# Altium schematic sheet PS_v1.SchDoc
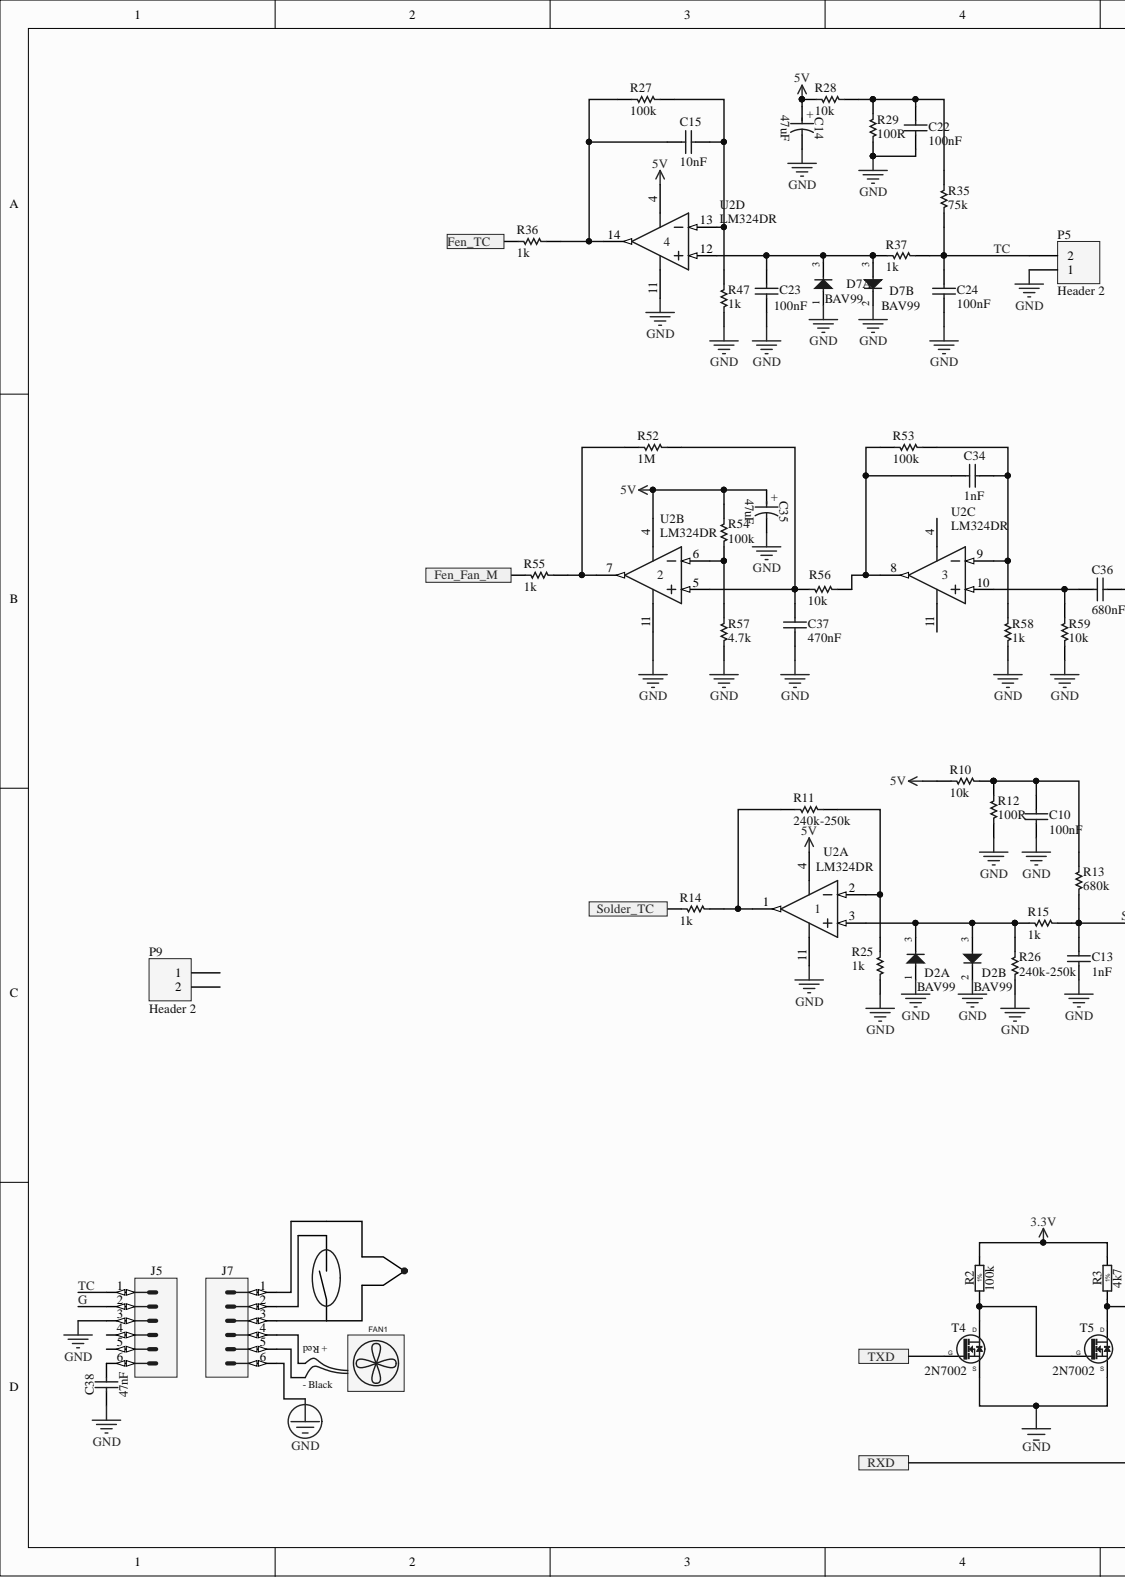
[diagram p0: PS_v1.SchDoc, left]
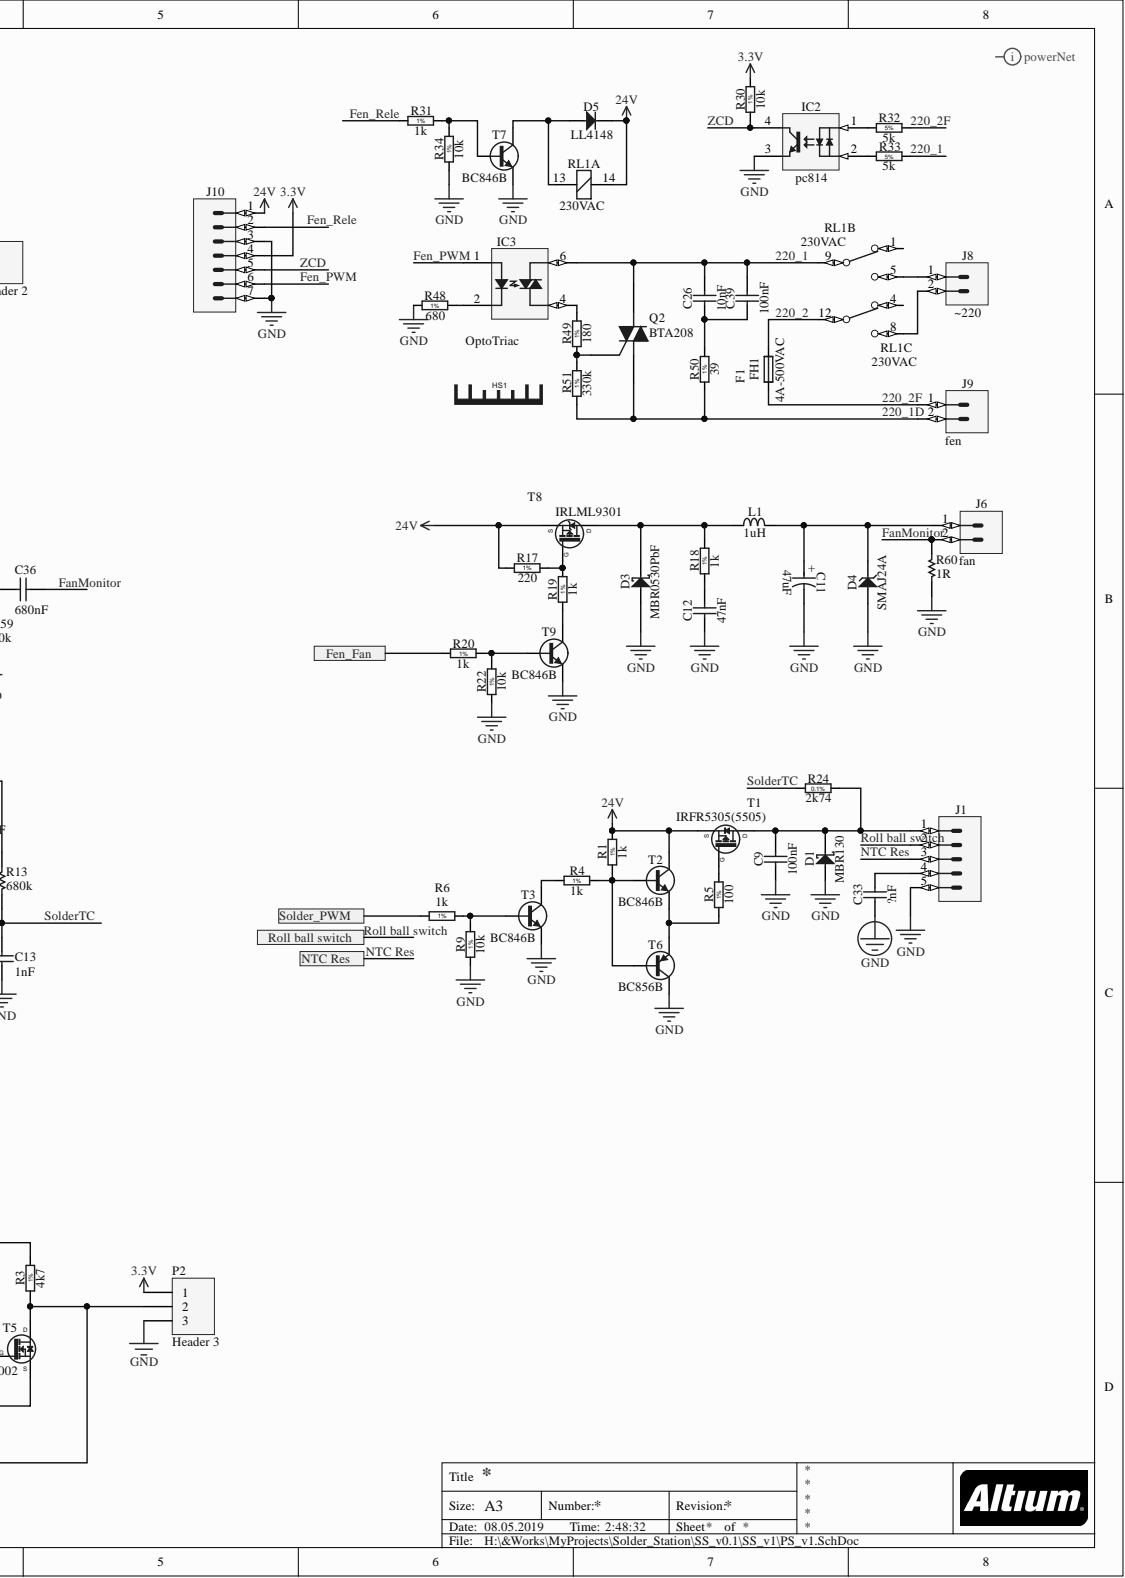
[diagram p1: PS_v1.SchDoc, right]

## Parts
C9  100nF  [CAPC2012X135N]
C10  value 100nF  [0805]  (hidden comment: C0805)
C11  47uF  [CAPAE660X540N]
C12  47nF  [CAPC2013X100N]
C13  value 1nF  [0805]  (hidden comment: C0805)
C14  47uF  [CAPAE660X540N]
C15  value 10nF  [0805]  (hidden comment: C0805)
C22  value 100nF  [0805]  (hidden comment: C0805)
C23  value 100nF  [0805]  (hidden comment: C0805)
C24  value 100nF  [0805]  (hidden comment: C0805)
C26  10nF  [CAPR1500-500X1800X1100]
C33  ?nF  [CAPC2013X100N]
C34  value 1nF  [0805]  (hidden comment: C0805)
C35  47uF  [CAPAE660X540N]
C36  value 680nF  [0805]  (hidden comment: C0805)
C37  value 470nF  [0805]  (hidden comment: C0805)
C38  47nF  [CAPC2013X100N]
C39  100nF  [CAPR2250-850X2650X1650]
D1  MBR130  [SOD-123FL]
D2  BAV99  [SOT95P240X110-3N]  (parts A,B)
D3  MBR0530PbF  [SOD3715X145N]
D4  SMAJ24A  [DIOM5226X262N]
D5  LL4148  [DIOMELF5025N]
D7  BAV99  [SOT95P240X110-3N]  (parts A,B)
F1  4A-500VAC  [FUSE_10X38_CERAMIC_H]
FAN1  Fan No Pin  [FAN_SUNON_MC30060V1-000U-A99]  (value not drawn)
FH1  Fuse Holder  [FUSH_BUSSMANN_1A3400-09_A]  (value not drawn)
HS1  Heat Sink No Pad  [HSINK_FISCHER_FK 242 SA 220 O_V]  (value not drawn)
IC2  pc814  [SOIC254P977X406-4N]
IC3  OptoTriac  [FAIRCHILD_MDIP 6L (SMD)]
J1  KK®  [MOLEX_22-27-2051]  (value not drawn)
J5  KK®  [MOLEX_22-27-2061]  (value not drawn)
J6  fan  [HARWIN_M22-2010205]
J7  KK®  [MOLEX_22-27-2061]  (value not drawn)
J8  ~220  [PHOENIX_1755736]
J9  fen  [PHOENIX_1755736]
J10  KK®  [MOLEX_22-04-1071]  (value not drawn)
L1  1uH  [INDC2012X110N]
P2  Header 3  [HDR1X3]
P5  Header 2  [HDR1X2]
P9  Header 2  [HDR1X2]
Q2  BTA208  [TO-220-V]
R1  1k  [RESC2012X60N]
R2  100k  [RESC2012X60N]
R3  4k7  [RESC2012X60N]
R4  1k  [RESC2012X60N]
R5  100  [RESC2012X60N]
R6  1k  [RESC2012X60N]
R9  10k  [RESC2012X60N]
R10  value 10k  [ERA-0805]  (hidden comment: ERA6Y)
R11  value 240k-250k  [ERA-0805]  (hidden comment: ERA6Y)
R12  value 100R  [ERA-0805]  (hidden comment: ERA6Y)
R13  value 680k  [ERA-0805]  (hidden comment: ERA6Y)
R14  value 1k  [ERA-0805]  (hidden comment: ERA6Y)
R15  value 1k  [ERA-0805]  (hidden comment: ERA6Y)
R17  220  [RESC2012X60N]
R18  1k  [RESC2012X60N]
R19  1k  [RESC2012X60N]
R20  1k  [RESC2012X60N]
R22  10k  [RESC2012X60N]
R24  2k74  [RESC2012X60N]
R25  value 1k  [ERA-0805]  (hidden comment: ERA6Y)
R26  value 240k-250k  [ERA-0805]  (hidden comment: ERA6Y)
R27  value 100k  [ERA-0805]  (hidden comment: ERA6Y)
R28  value 10k  [ERA-0805]  (hidden comment: ERA6Y)
R29  value 100R  [ERA-0805]  (hidden comment: ERA6Y)
R30  10k  [RESC2012X60N]
R31  1k  [RESC2012X60N]
R32  5k  [RES_WELWYN_W31]
R33  5k  [RES_VISHAY_AC03]
R34  10k  [RESC2012X60N]
R35  value 75k  [ERA-0805]  (hidden comment: ERA6Y)
R36  value 1k  [ERA-0805]  (hidden comment: ERA6Y)
R37  value 1k  [ERA-0805]  (hidden comment: ERA6Y)
R47  value 1k  [ERA-0805]  (hidden comment: ERA6Y)
R48  680  [RESC2012X60N]
R49  180  [RESC2012X60N]
R50  39  [RESC3216X65N]
R51  330k  [RESC2012X60N]
R52  value 1M  [ERA-0805]  (hidden comment: ERA6Y)
R53  value 100k  [ERA-0805]  (hidden comment: ERA6Y)
R54  value 100k  [ERA-0805]  (hidden comment: ERA6Y)
R55  value 1k  [ERA-0805]  (hidden comment: ERA6Y)
R56  value 10k  [ERA-0805]  (hidden comment: ERA6Y)
R57  value 4.7k  [ERA-0805]  (hidden comment: ERA6Y)
R58  value 1k  [ERA-0805]  (hidden comment: ERA6Y)
R59  value 10k  [ERA-0805]  (hidden comment: ERA6Y)
R60  value 1R  [ERA-0805]  (hidden comment: ERA6Y)
RL1  230VAC  [REL_FINDER_55.32]  (parts A,B,C)
T1  IRFR5305(5505)  [TO228P991X239-3N-R552X520]
T2  BC846B  [SOT95P230X110-3N]
T3  BC846B  [SOT95P230X110-3N]
T4  2N7002  [SOT95P240X130-3N]
T5  2N7002  [SOT95P240X130-3N]
T6  BC856B  [SOT95P230X110-3N]
T7  BC846B  [SOT95P230X110-3N]
T8  IRLML9301  [SOT95P237X112-3N]
T9  BC846B  [SOT95P230X110-3N]
U2  LM324DR  [D014_N]  (parts A,B,C,D)

## Ports
Fen_Fan, Fen_Fan_M, Fen_TC, NTC Res, Roll ball switch, RXD, Solder_PWM, Solder_TC, TXD

## Nets
3.3V: J10.4 P2.1 R2.2 R3.2 R30.2
5V: C14.1 C35.1 R10.1 R28.2 R54.2 U2.4
24V: D5.1 J10.1 R1.2 R17.1 RL1.14 T1.2 T2.3 T8.2
GND: C9.1 C10.1 C11.2 C12.1 C13.1 C14.2 C22.1 C23.1 C24.1 C33.1 C35.2 C37.1 C38.1 D1.2 D2.1 D2.2 D3.2 D4.2 D7.1 D7.2 IC2.3 J1.5 J5.3 J7.6 J10.3 J10.7 P2.3 P5.1 R9.1 R12.2 R22.1 R25.2 R26.2 R29.2 R34.1 R47.2 R48.1 R57.1 R58.1 R59.1 R60.1 T3.2 T4.2 T5.2 T6.3 T7.2 T9.2 U2.11
220_1: C26.2 C39.2 IC3.6 Q2.2 R33.2 RL1.9
220_1D: J9.2 Q2.1 R50.1 R51.1
220_2: FH1.2 RL1.12
220_2F: FH1.1 J9.1 R32.2
FanMonitor: C36.2 J6.2 R60.2
Fen_Fan: R20.1 ; port Fen_Fan
Fen_Fan_M: R55.1 ; port Fen_Fan_M
Fen_PWM: IC3.1 J10.6
Fen_Rele: J10.2 R31.1
Fen_TC: R36.2 ; port Fen_TC
G: J5.2
NTC Res: J1.3 ; port NTC Res
Roll ball switch: J1.2 ; port Roll ball switch
RXD: P2.2 R3.1 T5.3 ; port RXD
Solder_PWM: R6.1 ; port Solder_PWM
Solder_TC: R14.2 ; port Solder_TC
SolderTC: C13.2 R13.1 R15.2 R24.1
TC: C24.2 J5.1 P5.2 R35.2 R37.1
TXD: T4.1 ; port TXD
ZCD: IC2.4 J10.5 R30.1
NetC9_2: C9.2 D1.1 J1.1 R24.2 T1.3
NetC10_2: C10.2 R10.2 R12.1 R13.2
NetC11_1: C11.1 D4.1 J6.1 L1.2
NetC12_2: C12.2 R18.1
NetC15_1: C15.1 R27.2 R36.1 U2.14
NetC15_2: C15.2 R27.1 R47.1 U2.13
NetC22_2: C22.2 R28.1 R29.1 R35.1
NetC23_2: C23.2 D7.3 R37.2 U2.12
NetC26_1: C26.1 C39.1 R50.2
NetC33_2: C33.2 J1.4
NetC34_1: C34.1 R53.1 R56.2 U2.8
NetC34_2: C34.2 R53.2 R58.2 U2.9
NetC36_1: C36.1 R59.2 U2.10
NetC37_2: C37.2 R52.2 R56.1 U2.5
NetC38_2: C38.2 J5.6
NetD2_3: D2.3 R15.1 R26.1 U2.3
NetD3_1: D3.1 L1.1 R18.2 T8.3
NetD5_2: D5.2 RL1.13 T7.3
NetIC2_1: IC2.1 R32.1
NetIC2_2: IC2.2 R33.1
NetIC3_2: IC3.2 R48.2
NetIC3_4: IC3.4 R49.2
NetJ8_1: J8.1 RL1.5
NetJ8_2: J8.2 RL1.8
NetQ2_3: Q2.3 R49.1 R51.2
NetR1_1: R1.1 R4.2 T2.1 T6.1
NetR2_1: R2.1 T4.3 T5.1
NetR4_1: R4.1 T3.3
NetR5_1: R5.1 T2.2 T6.2
NetR5_2: R5.2 T1.1
NetR6_2: R6.2 R9.2 T3.1
NetR11_1: R11.1 R14.1 U2.1
NetR11_2: R11.2 R25.1 U2.2
NetR17_2: R17.2 R19.2 T8.1
NetR19_1: R19.1 T9.3
NetR20_2: R20.2 R22.2 T9.1
NetR31_2: R31.2 R34.2 T7.1
NetR52_1: R52.1 R55.2 U2.7
NetR54_1: R54.1 R57.2 U2.6
N00013:

Not connected: J5 4 5; J7 1 2 3 4 5; P9 1 2; RL1 1 4

## Library data of the parts
C9 = CC0805_100NF_50V_10%_X7R: Non-polarised capacitor; Manufacturer: GENERIC; Manufacturer1 Part Number: C2012X7R1H104K085AA; Part Description: SMD Multilayer Chip Ceramic Capacitor; Database Table Name: Capacitors SMD; TC: X7R; Tolerance: ±10%; Voltage: 50V; Case: 0805; Component Kind: Standard; Component Type: Standard; CreateDate: 03.12.2007; HelpURL: \\cern.ch\dfs\Applications\Altium\Datasheets\CC0805_X7R_TDK_C.pdf; LatestRevisionDate: 22.04.2015; Library Name: Capacitors.DbLib; Manufacturer1 ComponentHeight: 1mm; Manufacturer1 Example: TDK; Manufacturer Part Number: CC0805_100NF_50V_10%_X7R; Mounted: Yes; Part Number: CC0805_100NF_50V_10%_X7R; Pin Count: 2; Sense: No; SMD: Yes; Socket: No; Status: Preferred; Value: 100nF; Author: CERN DEM JLC
C10 C13 C15 C22-C24 C34 C36 C37 = C0805: Ceramic Chip Capacitor - Standard; Note: Footprint corresponds to dimensions for bulk cassette packaging. This case size has tightened tolerances.; Code_IPC: 2012[0805]; Code_JEITA: 0805; Component Kind: Standard; ComponentLink1Description: Manufacturer Link; ComponentLink1URL: http://www.kemet.com/; ComponentLink2Description: Datasheet; ComponentLink2URL: http://www.kemet.com/kemet/web/homepage/kechome.nsf/vapubfilesname/F3102TA.pdf/$file/F3102TA.pdf; DatasheetDocument: Mar-2001; LatestRevisionDate: 19-Mar-2004; LatestRevisionNote: Silkscreen size reduced.; Library Name: KEMET Chip Capacitor.IntLib; PackageDocument: Mar-2001; PackageReference: 0805; Published: 17-Jul-2002; Signal Integrity: C0805; Simulation: CAP; Publisher: Altium Limited
C11 = CAE660-540_47UF_35V_20%: Polarised capacitor; Manufacturer: PANASONIC; Manufacturer Part Number: EEEFK1V470AP; Part Description: Aluminum Electrolytic SMT Capacitor; Database Table Name: Capacitors SMD; Tolerance: ±20%; Voltage: 35V; Case: D; ComponentHeight: 5.8mm; Component Kind: Standard; Component Type: Standard; CreateDate: 03.12.2007; HelpURL: \\cern.ch\dfs\Applications\Altium\Datasheets\CAE_PANASONIC_FK.pdf; LatestRevisionDate: 05.11.2014; Library Name: Capacitors.DbLib; Mounted: Yes; Part Number: CAE660-540_47UF_35V_20%; Pin Count: 2; Sense: No; SMD: Yes; Socket: No; Status: Not Recommended; Value: 47uF; Author: CERN DEM JLC
C12 C33 C38 = CC0805_47NF_50V_10%_X7R: Non-polarised capacitor; Manufacturer: GENERIC; Manufacturer1 Part Number: C0805F473K5RACTU; Part Description: SMD Multilayer Chip Ceramic Capacitor; Database Table Name: Capacitors SMD; TC: X7R; Tolerance: ±10%; Voltage: 50V; Case: 0805; Component Kind: Standard; Component Type: Standard; CreateDate: 04.12.2013; HelpURL: \\cern.ch\dfs\Applications\Altium\Datasheets\CC0805_X7R_KEMET.pdf; LatestRevisionDate: 04.12.2013; Library Name: Capacitors.DbLib; Manufacturer1 ComponentHeight: 1mm; Manufacturer1 Example: KEMET; Manufacturer Part Number: CC0805_47NF_50V_10%_X7R; Mounted: Yes; Part Number: CC0805_47NF_50V_10%_X7R; Pin Count: 2; Sense: No; SMD: Yes; Socket: No; Status: Preferred; Author: CERN DEM JLC
  C12 C38: Value: 47nF
  C33: Value: ?nF
C14 C35 = CAE660-540_47UF_16V_20%: Polarised capacitor; Manufacturer: PANASONIC; Manufacturer Part Number: EEEFK1C470AP; Part Description: Aluminum Electrolytic SMT Capacitor; Database Table Name: Capacitors SMD; Tolerance: ±20%; Voltage: 16V; Case: D; ComponentHeight: 5.8mm; Component Kind: Standard; Component Type: Standard; CreateDate: 03.12.2007; HelpURL: \\cern.ch\dfs\Applications\Altium\Datasheets\CAE_PANASONIC_FK.pdf; LatestRevisionDate: 05.11.2014; Library Name: Capacitors.DbLib; Mounted: Yes; Part Number: CAE660-540_47UF_16V_20%; Pin Count: 2; Sense: No; SMD: Yes; Socket: No; Status: Not Recommended; Value: 47uF; Author: CERN DEM JLC
C26 = CPPR_10NF_300VAC_20%_1500-500X1800X1100: Non-polarised capacitor; Manufacturer: WIMA; Manufacturer Part Number: MKY22W21004B00MSSD; Part Description: Metallized Polypropylene RFI Capacitor Class Y2 (MKP-Y2); Database Table Name: Capacitors THD; Tolerance: ±20%; Voltage: 300VAC; Case: LS15 W5 x L18 x H11; ComponentHeight: 11mm; Component Kind: Standard; Component Type: Standard; CreateDate: 05.06.2008; HelpURL: \\cern.ch\dfs\Applications\Altium\Datasheets\CPPR_WIMA_MKP-Y2.pdf; LatestRevisionDate: 02.02.2012; Library Name: Capacitors.DbLib; Mounted: Yes; Part Number: CPPR_10NF_300VAC_20%_1500-500X1800X1100; Pin Count: 2; Sense: No; SMD: No; Socket: No; Status: None; Value: 10nF; Author: CERN DEM JLC
C39 = CPPR_100NF_300VAC_20%_TDK_B32023: Non-polarised capacitor; Manufacturer: TDK; Manufacturer Part Number: B32023A3104M000; Part Description: EMI Suppression Metallized Polypropylene Film Capacitor, Class  Y2; Database Table Name: Capacitors THD; Tolerance: ±20%; Voltage: 300VAC; Case: LS22.5 W8.5 x L26.5 x H16.5; ComponentHeight: 16.5mm; Component Kind: Standard; Component Type: Standard; CreateDate: 20.02.2017; HelpURL: \\cern.ch\dfs\Applications\Altium\Datasheets\CPPR_TDK_B32023.pdf; LatestRevisionDate: 20.04.2015; Library Name: Capacitors.DbLib; Mounted: Yes; Part Number: CPPR_100NF_300VAC_20%_TDK_B32023; Pin Count: 2; Sense: No; SMD: No; Socket: No; Status: None; Value: 100nF; Author: CERN DEM JLC
D1 = MBR140SFT1G: Schottky Diode; Manufacturer: ON SEMICONDUCTOR; Part Description: Schottky Power Rectifier; Bonding: No; Database Table Name: Diodes; Power: 1A; PressFit: No; Voltage: 40V; Case: SOD-123FL; ComponentHeight: 1.0mm; Component Kind: Standard; Component Type: Standard; CreateDate: 06.04.2009; Device: MBR130; HelpURL: \\cern.ch\dfs\Applications\Altium\Datasheets\MBR140SFT1G.pdf; LatestRevisionDate: 06.04.2009; Library Name: ICs And Semiconductors.DbLib; Manufacturer Part Number: MBR140SFT1G; Mounted: Yes; PackageDescription: Small Outline Diode Flat Lead (SOD-123FL), Body; 2.70mm L X 1.65mm W X 1.0mm H; Part Number: MBR140SFT1G; Pin Count: 2; Sense: No; SMD: Yes; Socket: No; Author: CERN DEM JLC
D2 D7 = BAV99: Serial Diode; Manufacturer: FAIRCHILD SEMICONDUCTOR; Part Description: Dual Series Signal Diode; Bonding: No; Database Table Name: Diodes; Power: 350mW; PressFit: No; Voltage: 70V; Case: SOT23-3; ComponentHeight: 1.1mm; Component Kind: Standard; Component Type: Standard; CreateDate: 06.05.2008; Device: BAV99; HelpURL: \\cern.ch\dfs\Applications\Altium\Datasheets\BAV99.pdf; LatestRevisionDate: 27.09.2012; Library Name: ICs And Semiconductors.DbLib; Manufacturer Part Number: BAV99; Mounted: Yes; PackageDescription: SOT23 3-Leads, Pitch 0.95mm - Body 1.4x3mm, IPC Medium Density; Part Number: BAV99; Pin Count: 3; Sense: No; SMD: Yes; Socket: No; Author: CERN DEM JLC
D3 = MBR0530PbF: Schottky Diode; Manufacturer: VISHAY HIGH POWER PRODUCTS; Bonding: No; Database Table Name: Diodes; Power: 500mA; PressFit: No; Voltage: 30V; Case: SOD-123; ComponentHeight: 1.45mm; Component Kind: Standard; Component Type: Standard; CreateDate: 26.01.2010; Device: MBR0530PbF; HelpURL: \\cern.ch\dfs\Applications\Altium\Datasheets\MBR0530PBF.pdf; LatestRevisionDate: 26.01.2010; Library Name: ICs And Semiconductors.DbLib; Manufacturer Part Number: MBR0530PbF; Mounted: Yes; PackageDescription: SOD Diode (SOD-123); 2.70mm W X 1.55mm L X 1.45mm H body, IPC Medium Density; Part Description: Schottky Diode; Part Number: MBR0530PbF; Pin Count: 2; Sense: No; SMD: Yes; Socket: No; Author: CERN DEM JLC
D4 = SMAJ24A: Transient Voltage Suppressor Diode (Uni-Directional); Manufacturer: BOURNS; Part Description: Surface Mount Uni-directional Transient Voltage Suppressor Diode; Bonding: No; Database Table Name: Diodes; Power: 400W; PressFit: No; Voltage: 24V; Case: DO-214AC; ComponentHeight: 2.62mm; Component Kind: Standard; Component Type: Standard; CreateDate: 27.06.2011; Device: SMAJ24A; HelpURL: \\cern.ch\dfs\Applications\Altium\Datasheets\SMAJ24A.pdf; LatestRevisionDate: 27.06.2011; Library Name: ICs And Semiconductors.DbLib; Manufacturer Part Number: SMAJ24A; Mounted: Yes; PackageDescription: DO-214-AC/SMA Diode, Molded Body; 5.21mm L X 2.605mm W X 2.62mm H, IPC Medium Density; Part Number: SMAJ24A; Pin Count: 2; Sense: No; SMD: Yes; Socket: No; Author: CERN DEM JLC
D5 = BYM10-50: Diode; Manufacturer: VISHAY GENERAL SEMICONDUCTOR; Part Description: Glass Passivated Junction Rectifier; Bonding: No; Database Table Name: Diodes; Power: 1A; PressFit: No; Voltage: 50V; Case: DO-213AB; ComponentHeight: 2.67mm; Component Kind: Standard; Component Type: Standard; CreateDate: 28.05.2008; Device: BYM10-50; HelpURL: \\cern.ch\dfs\Applications\Altium\Datasheets\BYM10.pdf; LatestRevisionDate: 02.09.2014; Library Name: ICs And Semiconductors.DbLib; Manufacturer Part Number: BYM10-50; Mounted: Yes; PackageDescription: DO-213-AB, MELF Diode, 2-Leads, Body 5.0x2.5mm, IPC Medium Density; Part Number: BYM10-50; Pin Count: 2; Sense: No; SMD: Yes; Socket: No; Status: Obsolete; Author: CERN DEM JLC
F1 = FUSE_MERSEN_W219232: Fuse; Manufacturer: MERSEN/FERRAZ SHAWMUT; Part Description: 10.3x38mm Cylindrical Ferrule Fuse-Links aM Type; Database Table Name: Fuses; PressFit: No; ComponentHeight: 10.4mm; Component Kind: Standard; Component Type: Standard; CreateDate: 07.10.2015; Family: Fuse; HelpURL: \\cern.ch\dfs\Applications\Altium\Datasheets\FUSE_MERSEN_W219232.pdf; LatestRevisionDate: 07.10.2015; Library Name: Eletro-mechanical.DbLib; Manufacturer Part Number: W219232; Mounted: Yes; PackageDescription: 10x38mm Cylindrical Ceramic Fuse; Part Number: FUSE_MERSEN_W219232; Pin Count: 0; Sense: No; SMD: No; Socket: Yes; Value: 4A-500VAC; Author: CERN DEM JMW
FAN1 = FAN_SUNON_MC30060V1-000U-A99: Fan; Manufacturer: SUNON; Part Description: 5VDC, 9500RPM, 30 x 30 x 6mm Axial Fan; Database Table Name: Heat-Sinks; PressFit: No; ComponentHeight: 6.9mm; Component Kind: Standard; Component Type: Standard; CreateDate: 26.01.2015; HelpURL: \\cern.ch\dfs\Applications\Altium\Datasheets\SUNON_MC30060V1-000U-A99.pdf; LatestRevisionDate: 26.01.2015; Library Name: Eletro-mechanical.DbLib; Manufacturer Part Number: MC30060V1-000U-A99; Mounted: Yes; PackageDescription: 5VDC, 9500RPM, 30 x 30 x 6mm Axial Fan; Part Number: FAN_SUNON_MC30060V1-000U-A99; Pin Count: 0; Sense: No; SMD: No; Socket: No; Status: None; Author: CERN DEM JLC
FH1 = FUSH_BUSSMANN_1A3400-09_A: Manufacturer Part Number: 2 x 1A3400-09; Part Description: 2 fuse clips (13/32") to receive a 10x38mm Fuse; Database Table Name: Fuses; PressFit: No; ComponentHeight: 18mm; Component Kind: Standard; Component Type: Standard; CreateDate: 04.06.2008; Description: Fuse Holder; Family: Fuse Holder; HelpURL: \\cern.ch\dfs\Applications\Altium\Datasheets\FUSH_BUSSMANN_1AXXXX.pdf; LatestRevisionDate: 21.03.2013; Library Name: Eletro-mechanical.DbLib; Manufacturer: BUSSMANN; Mounted: Yes; PackageDescription: 2 fuse clips (13/32") to receive a 10x38mm Fuse; Part Number: FUSH_BUSSMANN_1A3400-09_A; Pin Count: 2; Sense: No; SMD: No; Socket: No; Author: CERN DEM JLC
HS1 = HSINK_FISCHER_FK 242 SA 220 O_v: Heat Sink Without Pad; Manufacturer: FISCHER ELEKTRONIK; Part Description: TO-220 Heat Sink  Rth: 26°C/W - Vertical Mount; Database Table Name: Heat-Sinks; PressFit: No; ComponentHeight: 15.24mm; Component Kind: Standard; Component Type: Standard; CreateDate: 02.11.2009; HelpURL: \\cern.ch\dfs\Applications\Altium\Datasheets\HSINK_FISCHER_FK 242 SA 220 O.pdf; LatestRevisionDate: 10.10.2011; Library Name: Eletro-mechanical.DbLib; Manufacturer Part Number: FK 242 SA 220 O; Mounted: Yes; PackageDescription: TO-220 Heat Sink  Rth: 26°C/W - Vertical Mount; Part Number: HSINK_FISCHER_FK 242 SA 220 O_v; Pin Count: 0; Sense: No; SMD: No; Socket: No; Status: None; Author: CERN DEM JLC
IC2 = Optocoupler Type1: Optocoupler; Component Kind: Standard; Library Name: Schlib1.SchLib
IC3 = OptoTriac: Random Phase Snubberless Triac Driver; Manufacturer: ON Semiconductor; Component Kind: Standard; Device: OptoTriac; Holding Current (uA): -; LED Trigger Current (mA): -; Library Name: OptoTriac.SchLib; Mounting Technology: DIP, SMD; Off-state output Terminal Voltage (V): -; Package Type: PDIP-6, PDIP-6 GW; Peak Blocking Current (Max) (uA]): -; Peak On-State Voltage (V): -; Pin Count: -; Sefety Temperature Limit(°C): -; VISO (Min): -
J1 = MOLEX_22-27-2051: Connector 5 Male; Part Description: 2.54mm (.100") Pitch KK® Wire-to-Board Header, Vertical, with Friction Lock, 5 Circuits, Tin (Sn) Plating; Database Table Name: MOLEX; PressFit: No; ComponentHeight: 11.7mm; Component Kind: Standard; Component Type: Standard; CreateDate: 04.12.2008; Family: KK®; HelpURL: \\cern.ch\dfs\Applications\Altium\Datasheets\MOLEX_22-27-2051.pdf; LatestRevisionDate: 04.12.2008; Library Name: Connectors.DbLib; Manufacturer: MOLEX; Manufacturer Part Number: 22-27-2051; Mounted: Yes; Part Number: MOLEX_22-27-2051; Pin Count: 5; Sense: No; SMD: No; Socket: No; Status: None; Author: CERN DEM JLC
J5 J7 = MOLEX_22-27-2061: Connector 6 Male; Part Description: 2.54mm (.100") Pitch KK® Wire-to-Board Header, Vertical, with Friction Lock, 6 Circuits, Tin (Sn) Plating; Database Table Name: MOLEX; PressFit: No; ComponentHeight: 11.7mm; Component Kind: Standard; Component Type: Standard; CreateDate: 30.11.2011; Family: KK®; HelpURL: \\cern.ch\dfs\Applications\Altium\Datasheets\MOLEX_22-27-2061.pdf; LatestRevisionDate: 30.11.2011; Library Name: Connectors.DbLib; Manufacturer: MOLEX; Manufacturer Part Number: 22-27-2061; Mounted: Yes; Part Number: MOLEX_22-27-2061; Pin Count: 6; Sense: No; SMD: No; Socket: No; Status: None; Author: CERN DEM JMW
J6 = HARWIN_M22-2010205: Connector 2 Male; Part Description: 2 Contacts - Pitch 2mm - Single Row Through Mount Straight Header (Post Lead = 3.5mm, Tail Lead 3.4mm, Insulator 1.5mm); Database Table Name: HARWIN; PressFit: No; ComponentHeight: 5mm; Component Kind: Standard; Component Type: Standard; CreateDate: 14.04.2015; Family: Header 2; HelpURL: \\cern.ch\dfs\Applications\Altium\Datasheets\HARWIN_M22-2010205.pdf; LatestRevisionDate: 14.04.2015; Library Name: Connectors.DbLib; Manufacturer: HARWIN; Manufacturer Part Number: M22-2010205; Mounted: Yes; Part Number: HARWIN_M22-2010205; Pin Count: 2; Sense: No; SMD: No; Socket: No; Status: None; Author: CERN DEM JLC
J8 J9 = PHOENIX_1755736: Connector 2 Male; Manufacturer: PHOENIX CONTACT; Manufacturer Part Number: 1755736 (MSTBVA 2,5/2-G-5,08); Part Description: 12A, 250V, Pitch 5.08mm, 2 Positions Header; PressFit: No; ComponentHeight: 12mm; Component Kind: Standard; Component Type: Standard; CreateDate: 07.12.2010; Database Table Name: PHOENIX; HelpURL: \\cern.ch\dfs\Applications\Altium\Datasheets\PHOENIX_1755736.pdf; LatestRevisionDate: 07.12.2010; Library Name: Connectors.DbLib; Mounted: Yes; Part Number: PHOENIX_1755736; Pin Count: 2; Sense: No; SMD: No; Socket: No; Status: None; Author: CERN DEM JLC
J10 = MOLEX_22-04-1071: Connector 7 Male; Part Description: 2.5mm (.100") Pitch KK® Wire-to-Board Header, Vertical, with Friction Lock, 7 Circuits, Tin (Sn) Plating; Database Table Name: MOLEX; PressFit: No; ComponentHeight: 11.7mm; Component Kind: Standard; Component Type: Standard; CreateDate: 17.06.2013; Family: KK®; HelpURL: \\cern.ch\dfs\Applications\Altium\Datasheets\MOLEX_22-04-1071.pdf; LatestRevisionDate: 17.06.2013; Library Name: Connectors.DbLib; Manufacturer: MOLEX; Manufacturer Part Number: 22-04-1071; Mounted: Yes; Part Number: MOLEX_22-04-1071; Pin Count: 7; Sense: No; SMD: No; Socket: No; Status: None; Author: CERN DEM JLC
L1 = IND0805_1UH_20%_WURTH_74479775210: Inductor; Manufacturer: WURTH ELEKTRONIK; Part Description: SMD-Power Inductance (WE-PMI Series); Database Table Name: Inductors SMD; Power: 800mA; Resistance: 0.1R; Tolerance: ±20%; Case: 0805; ComponentHeight: 1.2mm; Component Kind: Standard; Component Type: Standard; CreateDate: 10.10.2012; HelpURL: \\cern.ch\dfs\Applications\Altium\Datasheets\IND0805_WURTH_74479775210.pdf; LatestRevisionDate: 08.04.2014; Library Name: Inductors & Transformers.DbLib; Manufacturer Part Number: 74479775210; Mounted: Yes; Part Number: IND0805_1UH_20%_WURTH_74479775210; Pin Count: 2; Sense: No; SMD: Yes; Socket: No; Status: None; Value: 1uH; Author: CERN DEM JLC
P2 = Header 3: Header, 3-Pin; Component Kind: Standard; LatestRevisionDate: 17-Jul-2002; LatestRevisionNote: Re-released for DXP Platform.; Library Name: Miscellaneous Connectors.IntLib; Signal Integrity: Connector; Publisher: Altium Limited
P5 P9 = Header 2: Header, 2-Pin; Component Kind: Standard; LatestRevisionDate: 17-Jul-2002; LatestRevisionNote: Re-released for DXP Platform.; Library Name: Miscellaneous Connectors.IntLib; Signal Integrity: Connector; Publisher: Altium Limited
Q2 = Triac: Silicon Bidirectional Triode Thyristor; Note: Pin 2 is electrically connected to Pin 4 (TAB); Component Kind: Standard; ComponentLink1Description: Manufacturer Link; ComponentLink1URL: http://www.motorola.com/; DatasheetVersion: 1999; LatestRevisionDate: 17-Jul-2002; LatestRevisionNote: Re-released for DXP Platform.; Library Name: Miscellaneous Devices.IntLib; PackageDescription: TO, Thru-Hole, Vertical, Heatsink Mounted; 3 In-Line Leads; Pitch 2.28 mm; PackageReference: 369-03; Published: 29-Sep-2000; Simulation: MAC15A8; Publisher: Altium Limited
R1 R4 R6 R18-R20 R31 = R0805_1K_1%_0.125W_100PPM: Resistor - 1%; Manufacturer: GENERIC; Manufacturer1 Part Number: NRC10F1001TRF; Part Description: General Purpose Thick Film Chip Resistor; Database Table Name: Resistors SMD; Power: 0.125W; PressFit: No; TC: ±100ppm/°C; Case: 0805; Component Kind: Standard; Component Type: Standard; CreateDate: 03.12.2007; HelpURL: \\cern.ch\dfs\Applications\Altium\Datasheets\R0805_NIC_NRC.pdf; LatestRevisionDate: 13.03.2008; Library Name: Resistors.DbLib; Manufacturer1 ComponentHeight: 0.6mm; Manufacturer1 Example: NIC COMPONENT; Manufacturer Part Number: R0805_1K_1%_0.125W_100PPM; Mounted: Yes; Part Number: R0805_1K_1%_0.125W_100PPM; Pin Count: 2; Sense: No; SMD: Yes; Socket: No; Status: Preferred; Tolerance: ±1%; Value: 1k; Author: CERN DEM JLC
R2 = R0805_100K_1%_0.125W_100PPM: Resistor - 1%; Manufacturer: GENERIC; Manufacturer1 Part Number: NRC10F1003TRF; Part Description: General Purpose Thick Film Chip Resistor; Database Table Name: Resistors SMD; Power: 0.125W; PressFit: No; TC: ±100ppm/°C; Case: 0805; Component Kind: Standard; Component Type: Standard; CreateDate: 03.12.2007; HelpURL: \\cern.ch\dfs\Applications\Altium\Datasheets\R0805_NIC_NRC.pdf; LatestRevisionDate: 13.03.2008; Library Name: Resistors.DbLib; Manufacturer1 ComponentHeight: 0.6mm; Manufacturer1 Example: NIC COMPONENT; Manufacturer Part Number: R0805_100K_1%_0.125W_100PPM; Mounted: Yes; Part Number: R0805_100K_1%_0.125W_100PPM; Pin Count: 2; Sense: No; SMD: Yes; Socket: No; Status: Preferred; Tolerance: ±1%; Value: 100k; Author: CERN DEM JLC
R3 = R0805_4K7_1%_0.125W_100PPM: Resistor - 1%; Manufacturer: GENERIC; Manufacturer1 Part Number: NRC10F4701TRF; Part Description: General Purpose Thick Film Chip Resistor; Database Table Name: Resistors SMD; Power: 0.125W; PressFit: No; TC: ±100ppm/°C; Case: 0805; Component Kind: Standard; Component Type: Standard; CreateDate: 03.12.2007; HelpURL: \\cern.ch\dfs\Applications\Altium\Datasheets\R0805_NIC_NRC.pdf; LatestRevisionDate: 13.03.2008; Library Name: Resistors.DbLib; Manufacturer1 ComponentHeight: 0.6mm; Manufacturer1 Example: NIC COMPONENT; Manufacturer Part Number: R0805_4K7_1%_0.125W_100PPM; Mounted: Yes; Part Number: R0805_4K7_1%_0.125W_100PPM; Pin Count: 2; Sense: No; SMD: Yes; Socket: No; Status: Preferred; Tolerance: ±1%; Value: 4k7; Author: CERN DEM JLC
R5 = R0805_100R_1%_0.125W_100PPM: Resistor - 1%; Manufacturer: GENERIC; Manufacturer1 Part Number: NRC10F4700TRF; Part Description: General Purpose Thick Film Chip Resistor; Database Table Name: Resistors SMD; Power: 0.125W; PressFit: No; TC: ±100ppm/°C; Case: 0805; Component Kind: Standard; Component Type: Standard; CreateDate: 03.12.2007; HelpURL: \\cern.ch\dfs\Applications\Altium\Datasheets\R0805_NIC_NRC.pdf; LatestRevisionDate: 13.03.2008; Library Name: Resistors.DbLib; Manufacturer1 ComponentHeight: 0.6mm; Manufacturer1 Example: NIC COMPONENT; Manufacturer Part Number: R0805_100R_1%_0.125W_100PPM; Mounted: Yes; Part Number: R0805_100R_1%_0.125W_100PPM; Pin Count: 2; Sense: No; SMD: Yes; Socket: No; Status: Preferred; Tolerance: ±1%; Value: 100; Author: CERN DEM JLC
R9 R22 R30 R34 = R0805_10K_1%_0.125W_100PPM: Resistor - 1%; Manufacturer: GENERIC; Manufacturer1 Part Number: NRC10F1002TRF; Part Description: General Purpose Thick Film Chip Resistor; Database Table Name: Resistors SMD; Power: 0.125W; PressFit: No; TC: ±100ppm/°C; Case: 0805; Component Kind: Standard; Component Type: Standard; CreateDate: 03.12.2007; HelpURL: \\cern.ch\dfs\Applications\Altium\Datasheets\R0805_NIC_NRC.pdf; LatestRevisionDate: 13.03.2008; Library Name: Resistors.DbLib; Manufacturer1 ComponentHeight: 0.6mm; Manufacturer1 Example: NIC COMPONENT; Manufacturer Part Number: R0805_10K_1%_0.125W_100PPM; Mounted: Yes; Part Number: R0805_10K_1%_0.125W_100PPM; Pin Count: 2; Sense: No; SMD: Yes; Socket: No; Status: Preferred; Tolerance: ±1%; Value: 10k; Author: CERN DEM JLC
R10-R15 R25-R29 R35-R37 R47 R52-R60 = ERA6Y: Rectangular Thick Film Chip Resistor, 10 Ohm to 1M Ohm Range, 0.1% and 0.5% Tolerance, 0805 Size, 0.1 W; Note: If flow soldering, adjust pad width of PCB Footprint to 0.7 - 0.8 times the width of the resistor.; Code_IPC: 2012 [0805]; Component Kind: Standard; ComponentLink1Description: Manufacturer Link; ComponentLink1URL: http://www.panasonic.com/industrial/components/resistive_products.htm; ComponentLink2Description: Datasheet; ComponentLink2URL: http://www.panasonic.com/industrial/components/pdf/AOA0000CE8.pdf; LatestRevisionDate: 19-Mar-2004; LatestRevisionNote: Silkscreen size reduced.; Library Name: Panasonic Resistor.IntLib; PackageDocument: Mar-2003; PackageReference: ERA-0805; Published: 17-Dec-2003; Signal Integrity: Res; Simulation: RESISTOR; Publisher: Altium Limited
R17 = R0805_220R_1%_0.125W_100PPM: Resistor - 1%; Manufacturer: GENERIC; Manufacturer1 Part Number: NRC10F2200TRF; Part Description: General Purpose Thick Film Chip Resistor; Database Table Name: Resistors SMD; Power: 0.125W; PressFit: No; TC: ±100ppm/°C; Case: 0805; Component Kind: Standard; Component Type: Standard; CreateDate: 03.12.2007; HelpURL: \\cern.ch\dfs\Applications\Altium\Datasheets\R0805_NIC_NRC.pdf; LatestRevisionDate: 13.03.2008; Library Name: Resistors.DbLib; Manufacturer1 ComponentHeight: 0.6mm; Manufacturer1 Example: NIC COMPONENT; Manufacturer Part Number: R0805_220R_1%_0.125W_100PPM; Mounted: Yes; Part Number: R0805_220R_1%_0.125W_100PPM; Pin Count: 2; Sense: No; SMD: Yes; Socket: No; Status: Preferred; Tolerance: ±1%; Value: 220; Author: CERN DEM JLC
R24 = R0805_2K74_0.1%_0.125W_25PPM: Resistor - 0.1%; Manufacturer: GENERIC; Manufacturer1 Part Number: ERA6AEB2741V; Part Description: Thin Film Chip Resistor; Database Table Name: Resistors SMD; Power: 0.125W; PressFit: No; TC: ±25ppm; Case: 0805; Component Kind: Standard; Component Type: Standard; CreateDate: 18.10.2010; HelpURL: \\cern.ch\dfs\Applications\Altium\Datasheets\R0805_PANASONIC_ERA.pdf; LatestRevisionDate: 18.10.2010; Library Name: Resistors.DbLib; Manufacturer1 ComponentHeight: 0.6mm; Manufacturer1 Example: PANASONIC; Manufacturer Part Number: R0805_2K74_0.1%_0.125W_25PPM; Mounted: Yes; Part Number: R0805_2K74_0.1%_0.125W_25PPM; Pin Count: 2; Sense: No; SMD: Yes; Socket: No; Status: Not Recommended; Tolerance: ±0.1%; Value: 2k74; Author: CERN DEM JLC
R32 = RWW_0R051_5%_3W_500PPM_WELWYN_W31: Resistor - 5%; Manufacturer: TT WELWYN; Manufacturer Part Number: W31-R051 JI; Part Description: Cement Coated Wirewound Resistor; Database Table Name: Resistors THD; Power: 3W; PressFit: No; TC: ±500ppm/°C; Case: LS20.32 L13 x D5.6; ComponentHeight: 5.6mm; Component Kind: Standard; Component Type: Standard; CreateDate: 10.07.2015; HelpURL: \\cern.ch\dfs\Applications\Altium\Datasheets\RWW_WELWYN_W31.pdf; LatestRevisionDate: 10.07.2015; Library Name: Resistors.DbLib; Mounted: Yes; Part Number: RWW_0R051_5%_3W_500PPM_WELWYN_W31; Pin Count: 2; Sense: No; SMD: No; Socket: No; Status: None; Tolerance: ±5%; Value: 5k; Author: CERN DEM JMW
R33 = RWW_0R47_5%_3W_80PPM_VISHAY_AC03: Resistor - 5%; Manufacturer: VISHAY DRALORIC; Manufacturer Part Number: AC03000004707JAC00; Part Description: Cemented Wirewound Resistor; Database Table Name: Resistors THD; Power: 3W; PressFit: No; TC: -10 -80ppm/°C; Case: LS20.32 L13 x D4.8; ComponentHeight: 4.8mm; Component Kind: Standard; Component Type: Standard; CreateDate: 10.07.2015; HelpURL: \\cern.ch\dfs\Applications\Altium\Datasheets\RWW_VISHAY_AC03.pdf; LatestRevisionDate: 10.07.2015; Library Name: Resistors.DbLib; Mounted: Yes; Part Number: RWW_0R47_5%_3W_80PPM_VISHAY_AC03; Pin Count: 2; Sense: No; SMD: No; Socket: No; Status: None; Tolerance: ±5%; Value: 5k; Author: CERN DEM JMW
R48 = R0805_680R_1%_0.125W_100PPM: Resistor - 1%; Manufacturer: GENERIC; Manufacturer1 Part Number: NRC10F6800TRF; Part Description: General Purpose Thick Film Chip Resistor; Database Table Name: Resistors SMD; Power: 0.125W; PressFit: No; TC: ±100ppm/°C; Case: 0805; Component Kind: Standard; Component Type: Standard; CreateDate: 03.12.2007; HelpURL: \\cern.ch\dfs\Applications\Altium\Datasheets\R0805_NIC_NRC.pdf; LatestRevisionDate: 13.03.2008; Library Name: Resistors.DbLib; Manufacturer1 ComponentHeight: 0.6mm; Manufacturer1 Example: NIC COMPONENT; Manufacturer Part Number: R0805_680R_1%_0.125W_100PPM; Mounted: Yes; Part Number: R0805_680R_1%_0.125W_100PPM; Pin Count: 2; Sense: No; SMD: Yes; Socket: No; Status: Preferred; Tolerance: ±1%; Value: 680; Author: CERN DEM JLC
R49 = R0805_180R_1%_0.125W_100PPM: Resistor - 1%; Manufacturer: GENERIC; Manufacturer1 Part Number: NRC10F1800TRF; Part Description: General Purpose Thick Film Chip Resistor; Database Table Name: Resistors SMD; Power: 0.125W; PressFit: No; TC: ±100ppm/°C; Case: 0805; Component Kind: Standard; Component Type: Standard; CreateDate: 03.12.2007; HelpURL: \\cern.ch\dfs\Applications\Altium\Datasheets\R0805_NIC_NRC.pdf; LatestRevisionDate: 13.03.2008; Library Name: Resistors.DbLib; Manufacturer1 ComponentHeight: 0.6mm; Manufacturer1 Example: NIC COMPONENT; Manufacturer Part Number: R0805_180R_1%_0.125W_100PPM; Mounted: Yes; Part Number: R0805_180R_1%_0.125W_100PPM; Pin Count: 2; Sense: No; SMD: Yes; Socket: No; Status: Preferred; Tolerance: ±1%; Value: 180; Author: CERN DEM JLC
R50 = R1206_39R_1%_0.25W_100PPM: Resistor - 1%; Manufacturer: GENERIC; Manufacturer1 Part Number: NRC12F39R0TRF; Part Description: General Purpose Thick Film Chip Resistor; Database Table Name: Resistors SMD; Power: 0.25W; PressFit: No; TC: ±100ppm/°C; Case: 1206; Component Kind: Standard; Component Type: Standard; CreateDate: 03.12.2007; HelpURL: \\cern.ch\dfs\Applications\Altium\Datasheets\R1206_NIC_NRC.pdf; LatestRevisionDate: 13.03.2008; Library Name: Resistors.DbLib; Manufacturer1 ComponentHeight: 0.65mm; Manufacturer1 Example: NIC COMPONENT; Manufacturer Part Number: R1206_39R_1%_0.25W_100PPM; Mounted: Yes; Part Number: R1206_39R_1%_0.25W_100PPM; Pin Count: 2; Sense: No; SMD: Yes; Socket: No; Status: Preferred; Tolerance: ±1%; Value: 39; Author: CERN DEM JLC
R51 = R0805_330K_1%_0.125W_100PPM: Resistor - 1%; Manufacturer: GENERIC; Manufacturer1 Part Number: NRC10F3303TRF; Part Description: General Purpose Thick Film Chip Resistor; Database Table Name: Resistors SMD; Power: 0.125W; PressFit: No; TC: ±100ppm/°C; Case: 0805; Component Kind: Standard; Component Type: Standard; CreateDate: 03.12.2007; HelpURL: \\cern.ch\dfs\Applications\Altium\Datasheets\R0805_NIC_NRC.pdf; LatestRevisionDate: 13.03.2008; Library Name: Resistors.DbLib; Manufacturer1 ComponentHeight: 0.6mm; Manufacturer1 Example: NIC COMPONENT; Manufacturer Part Number: R0805_330K_1%_0.125W_100PPM; Mounted: Yes; Part Number: R0805_330K_1%_0.125W_100PPM; Pin Count: 2; Sense: No; SMD: Yes; Socket: No; Status: Preferred; Tolerance: ±1%; Value: 330k; Author: CERN DEM JLC
RL1 = REL_FINDER_55.32.8.230.0040: DPDT General Purpose Relay, 55.32 Serie (Must be Used with FINDER 94.12 Socket); Part Description: 10A, 2xSPDT General Purpose Relay, 55.32 Serie (Must be used with FINDER 94.12 Socket); Database Table Name: Relays; PressFit: No; ComponentHeight: 37.2mm; Component Kind: Standard; Component Type: Standard; CreateDate: 28.06.2011; HelpURL: \\cern.ch\dfs\Applications\Altium\Datasheets\REL_FINDER_55.32.pdf; LatestRevisionDate: 28.06.2011; Library Name: Eletro-mechanical.DbLib; Manufacturer: FINDER; Manufacturer Part Number: 55.32.8.230.0040; Mounted: Yes; PackageDescription: 8 Leads, Row Spacing 13.2mm; Body 20.7mm x 27.7mm, FINDER_55.32 Series; Part Number: REL_FINDER_55.32.8.230.0040; Pin Count: 8; Sense: No; SMD: No; Socket: Yes; Status: None; Value: 230VAC; Author: CERN DEM JLC
T1 = IRFR9220PBF: P-CHANNEL MOSFET WITH DIODE; Manufacturer: VISHAY SILICONIX; Part Description: -200V -3.6A P-Channel Power MOSFET; Bonding: No; Database Table Name: Transistors; PressFit: No; Case: DPAK; ComponentHeight: 2.38mm; Component Kind: Standard; Component Type: Standard; CreateDate: 18.03.2013; Device: IRFR5305(5505); HelpURL: \\cern.ch\dfs\Applications\Altium\Datasheets\IRFR9220PBF.pdf; LatestRevisionDate: 18.03.2013; Library Name: ICs And Semiconductors.DbLib; Manufacturer Part Number: IRFR9220PBF; Mounted: Yes; PackageDescription: TO-252AA DPAK TO, 2.28mm pitch; 2 pin,9.905mm L X 6.095mm W X 2.39mm H body, IPC Medium Density; Part Number: IRFR9220PBF; Pin Count: 3; Sense: No; SMD: Yes; Socket: No; Author: CERN DEM JLC
T2 T3 T7 T9 = BC846B: NPN Transistor; Manufacturer: NXP SEMICONDUCTORS; Part Description: 65 V 100mA NPN general-purpose transistors; Bonding: No; Database Table Name: Transistors; PressFit: No; Case: SOT23-3; ComponentHeight: 1.1mm; Component Kind: Standard; Component Type: Standard; CreateDate: 10.03.2011; Device: BC846B; HelpURL: \\cern.ch\dfs\Applications\Altium\Datasheets\BC846B.pdf; LatestRevisionDate: 10.03.2011; Library Name: ICs And Semiconductors.DbLib; Manufacturer Part Number: BC846B; Mounted: Yes; PackageDescription: SOT23, 3 pin, Pitch 0.95mm - 1.3mm W X 2.9mm L X 1.1mm H, IPC Medium Density; Part Number: BC846B; Pin Count: 3; Sense: No; SMD: Yes; Socket: No; Author: CERN DEM JLC
T4 T5 = 2N7002: N-CHANNEL MOSFET WITH DIODE; Manufacturer: FAIRCHILD SEMICONDUCTOR; Part Description: 60V 280mA N-Channel Enhancement Mode Field Effect Transistor (FET); Bonding: No; Database Table Name: Transistors; PressFit: No; Case: SOT23-3; ComponentHeight: 1.3mm; Component Kind: Standard; Component Type: Standard; CreateDate: 20.08.2008; Device: 2N7002; HelpURL: \\cern.ch\dfs\Applications\Altium\Datasheets\2N7002.pdf; LatestRevisionDate: 07.04.2009; Library Name: ICs And Semiconductors.DbLib; Manufacturer Part Number: 2N7002; Mounted: Yes; PackageDescription: SOT23 3-Leads, Pitch 0.95mm - Body 1.3x2.9mm, IPC Medium Density; Part Number: 2N7002; Pin Count: 3; Sense: No; SMD: Yes; Socket: No; Author: CERN DEM JLC
T6 = BC857B: PNP Transistor; Manufacturer: NXP SEMICONDUCTORS; Part Description: 45V 100mA PNP general-purpose transistors; Bonding: No; Database Table Name: Transistors; PressFit: No; Case: SOT23-3; ComponentHeight: 1.1mm; Component Kind: Standard; Component Type: Standard; CreateDate: 14.06.2011; Device: BC856B; HelpURL: \\cern.ch\dfs\Applications\Altium\Datasheets\BC857B.pdf; LatestRevisionDate: 14.06.2011; Library Name: ICs And Semiconductors.DbLib; Manufacturer Part Number: BC857B; Mounted: Yes; PackageDescription: SOT23, 3 pin, Pitch 0.95mm - 1.3mm W X 2.9mm L X 1.1mm H, IPC Medium Density; Part Number: BC857B; Pin Count: 3; Sense: No; SMD: Yes; Socket: No; Author: CERN DEM JLC
T8 = IRLML6402PBF: P-CHANNEL MOSFET WITH ZENER DIODE; Manufacturer: INTERNATIONAL RECTIFIER; Part Description: -20V -3.7A P-Channel HEXFET® Power MOSFET; Bonding: No; Database Table Name: Transistors; PressFit: No; Case: SOT23-3; ComponentHeight: 1.12mm; Component Kind: Standard; Component Type: Standard; CreateDate: 29.08.2011; Device: IRLML9301; HelpURL: \\cern.ch\dfs\Applications\Altium\Datasheets\IRLML6402PBF.pdf; LatestRevisionDate: 29.08.2011; Library Name: ICs And Semiconductors.DbLib; Manufacturer Part Number: IRLML6402PBF; Mounted: Yes; PackageDescription: SOT, 0.95mm, pitch; 3 pin,1.30mm W X 2.92mm L X 1.12mm H body, IPC Medium Density; Part Number: IRLML6402PBF; Pin Count: 3; Sense: No; SMD: Yes; Socket: No; Author: CERN DEM JLC
U2 = LM324DR: Quadruple Operational Amplifier; Code_IPC: SOIC127P600-14; Component Kind: Standard; ComponentLink1Description: Manufacturer Link; ComponentLink1URL: http://www.ti.com/; ComponentLink2Description: Datasheet; ComponentLink2URL: http://www-s.ti.com/sc/ds/lm324.pdf; DatasheetVersion: Jan-1989; LatestRevisionDate: 13-Apr-2006; LatestRevisionNote: IPC-7351 Footprint Added.; Library Name: TI Operational Amplifier.IntLib; PackageDescription: 14-Pin Small Outline Integrated Circuit 1.27 mm Pitch; PackageReference: D014; PackageVersion: Jan-1998; PCB3D: D014; Published: 15-Apr-2002; Publisher: Altium Limited
